# Altium schematic sheet 03_DRAM.SchDoc
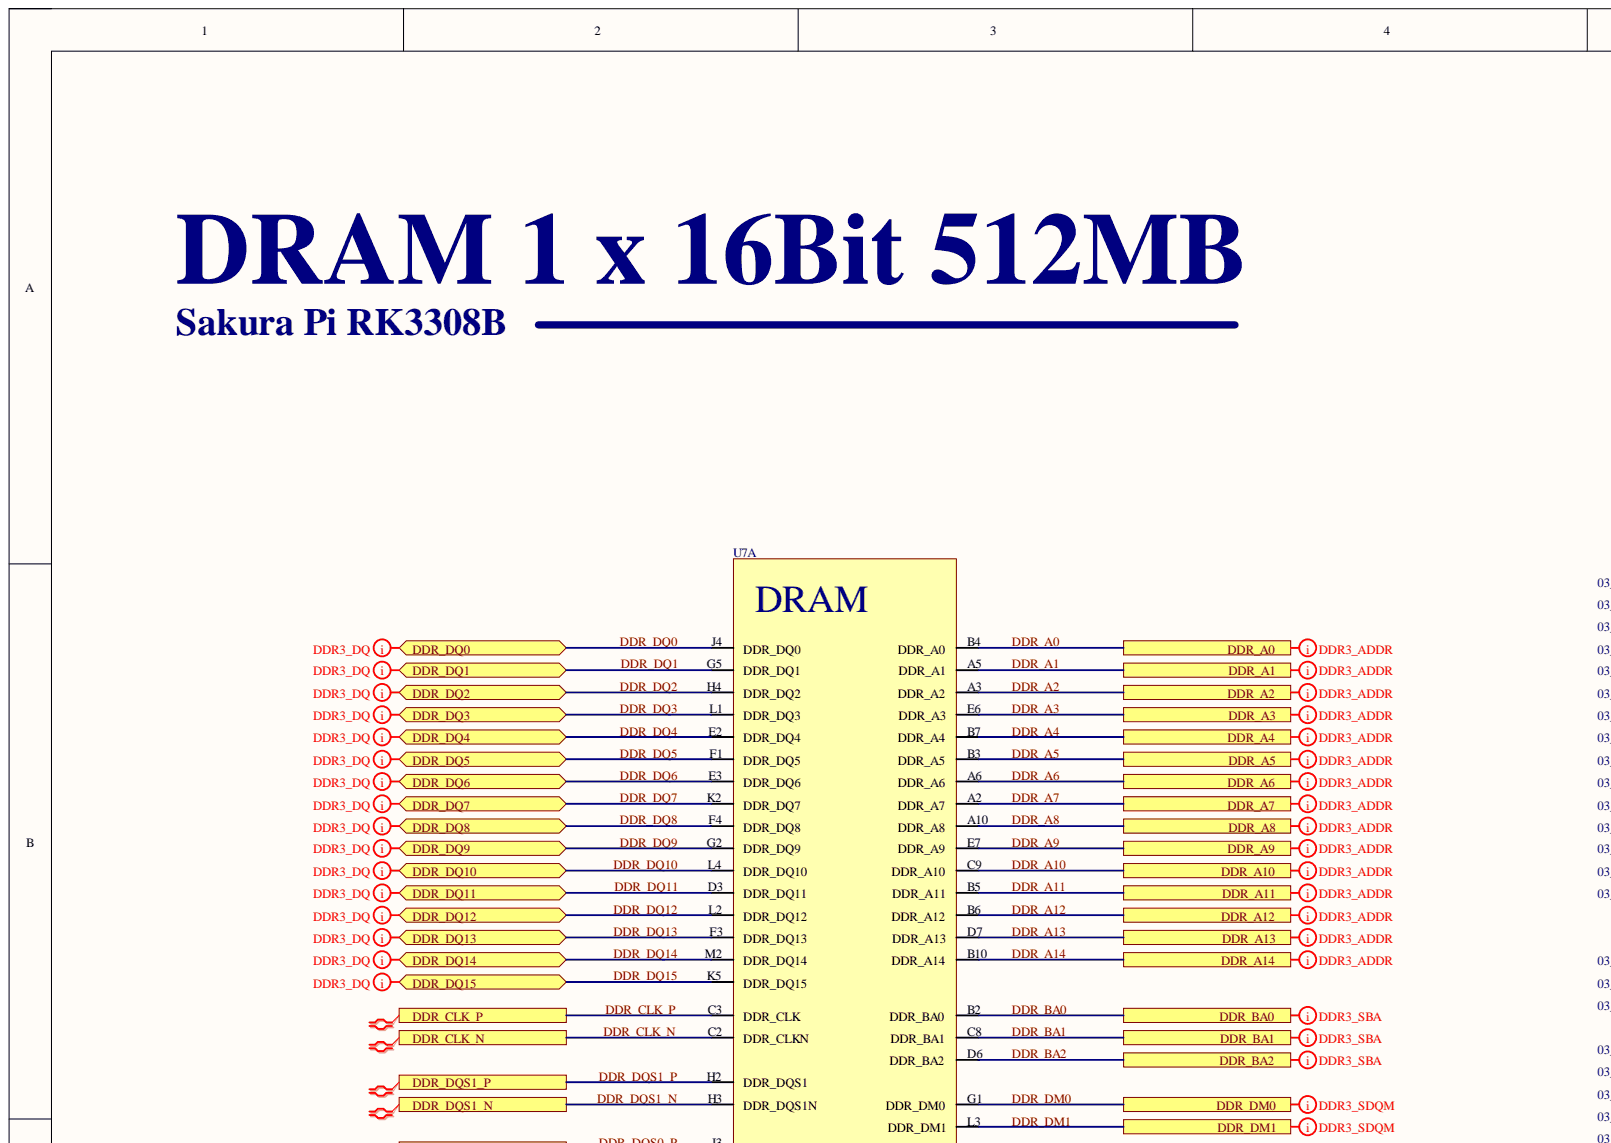
[diagram p0: 03_DRAM.SchDoc, top left]
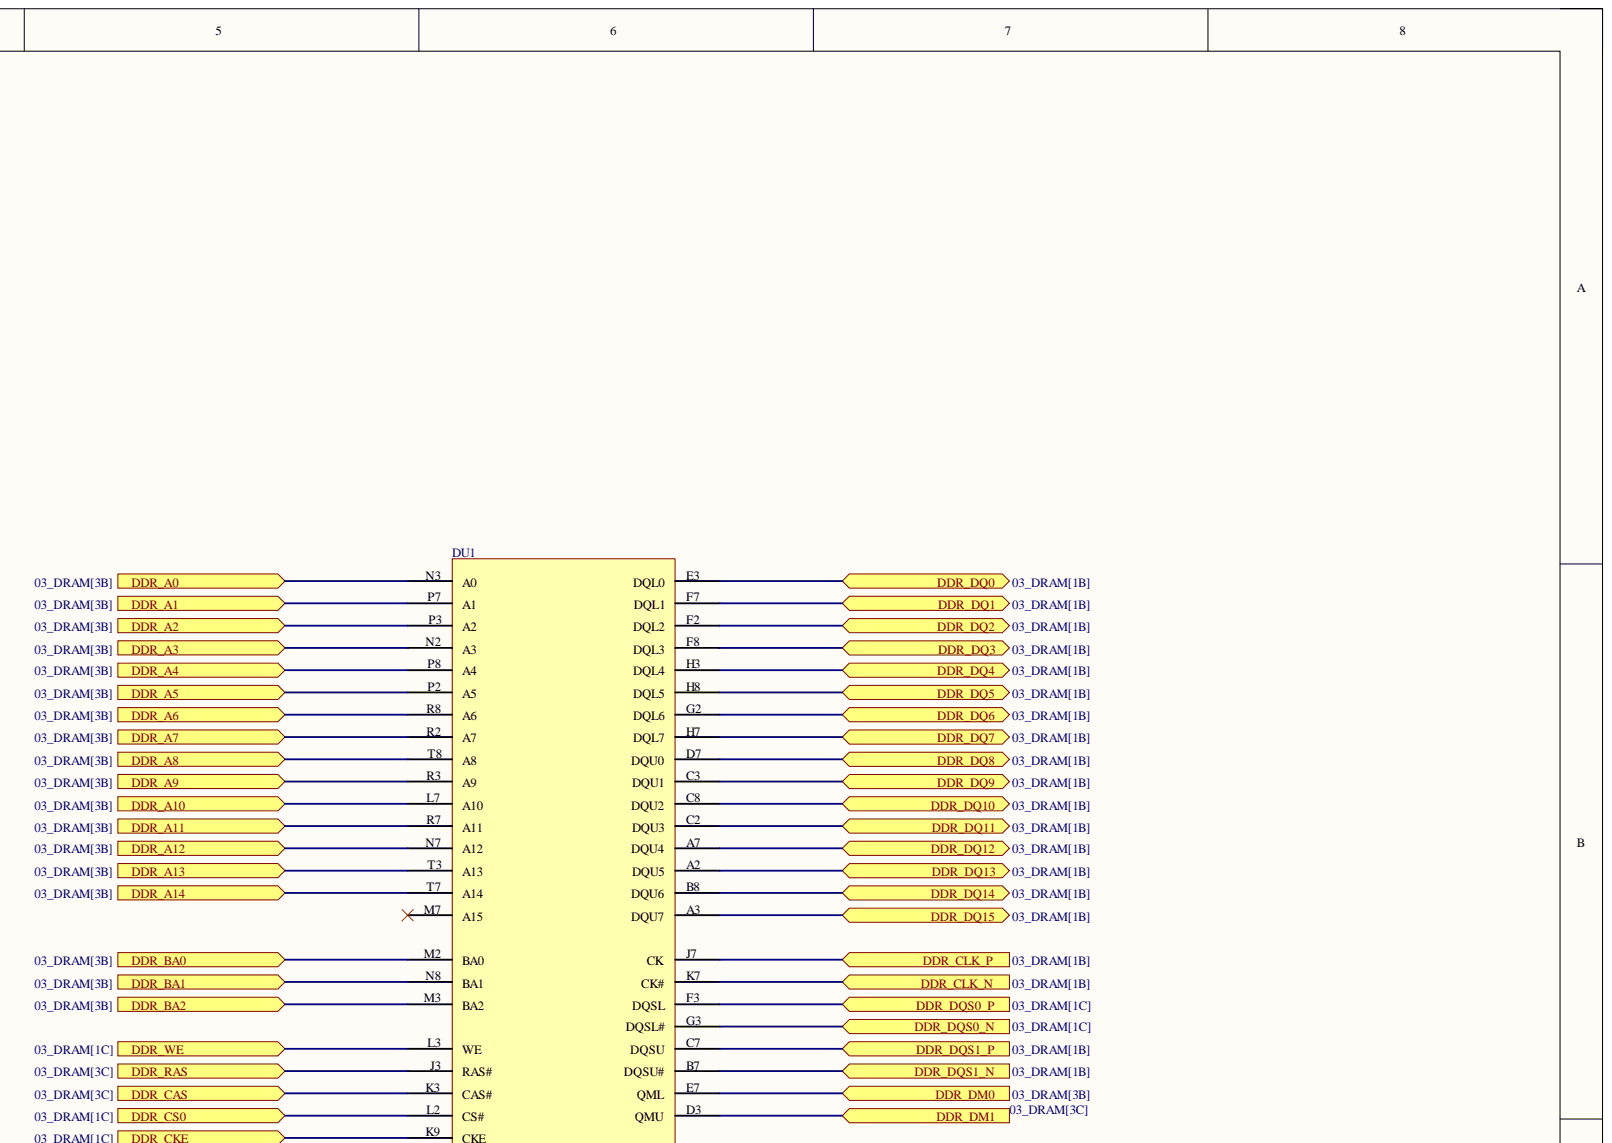
[diagram p1: 03_DRAM.SchDoc, top right]
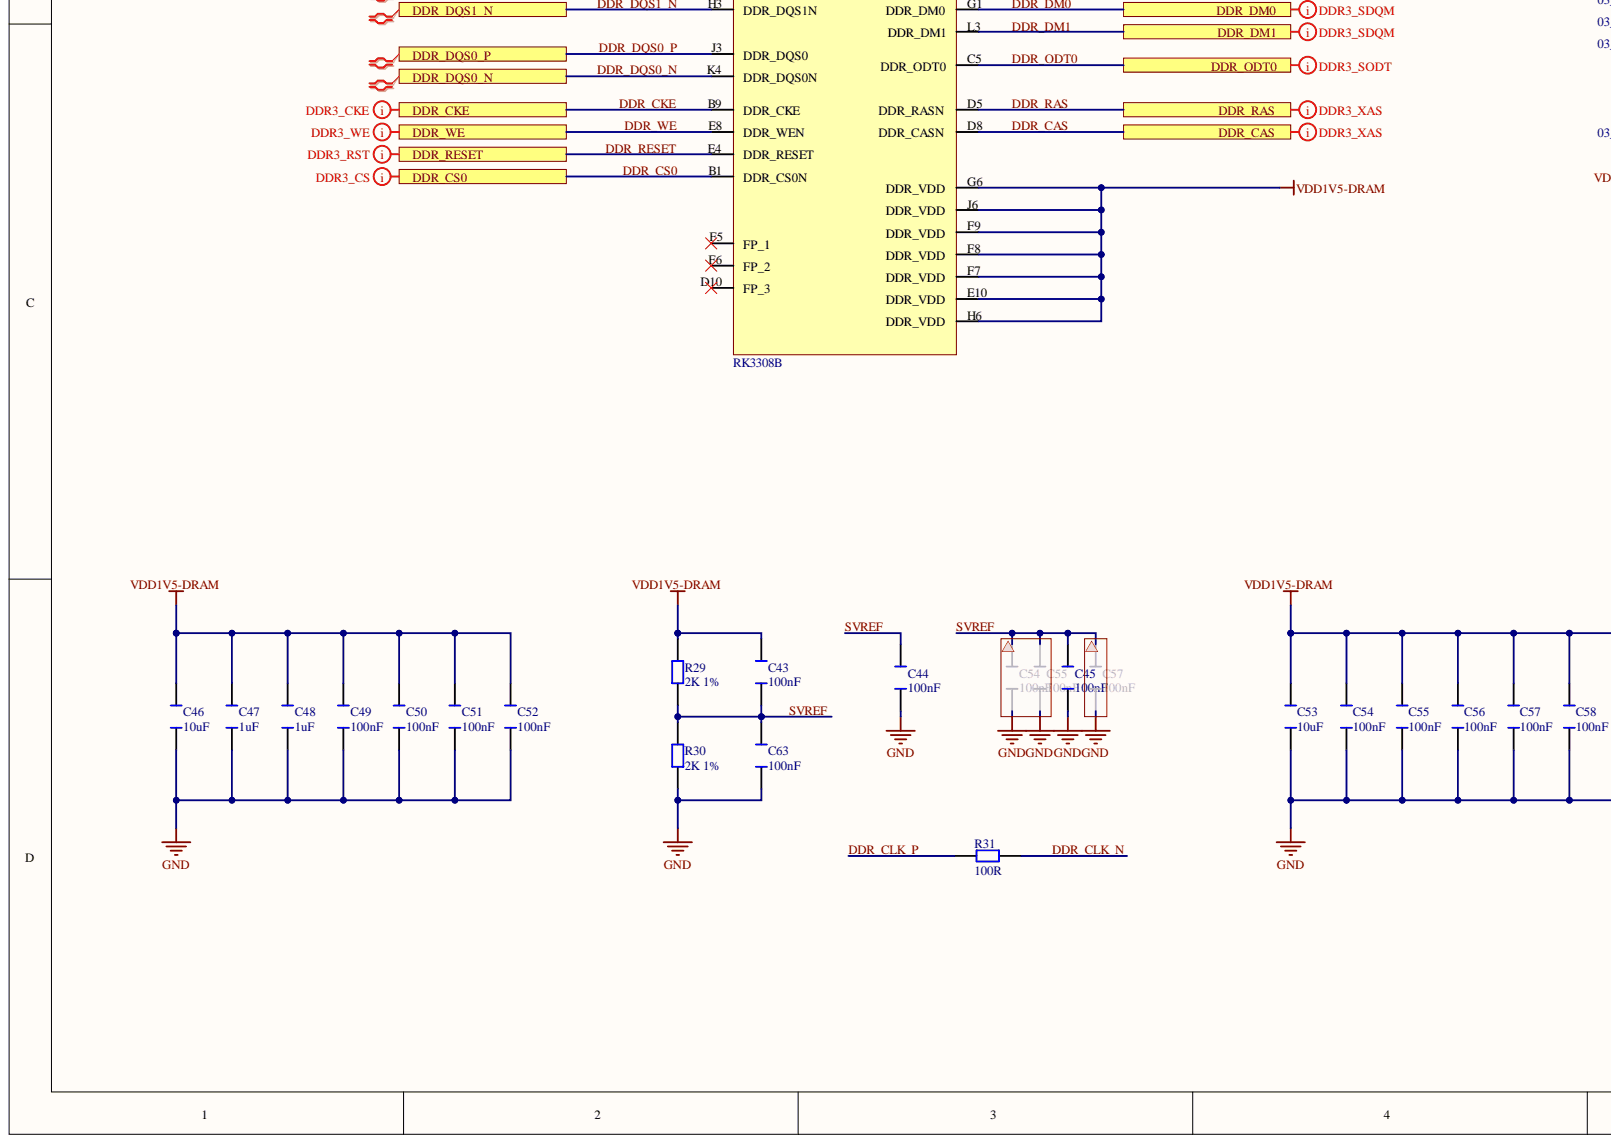
[diagram p2: 03_DRAM.SchDoc, bottom left]
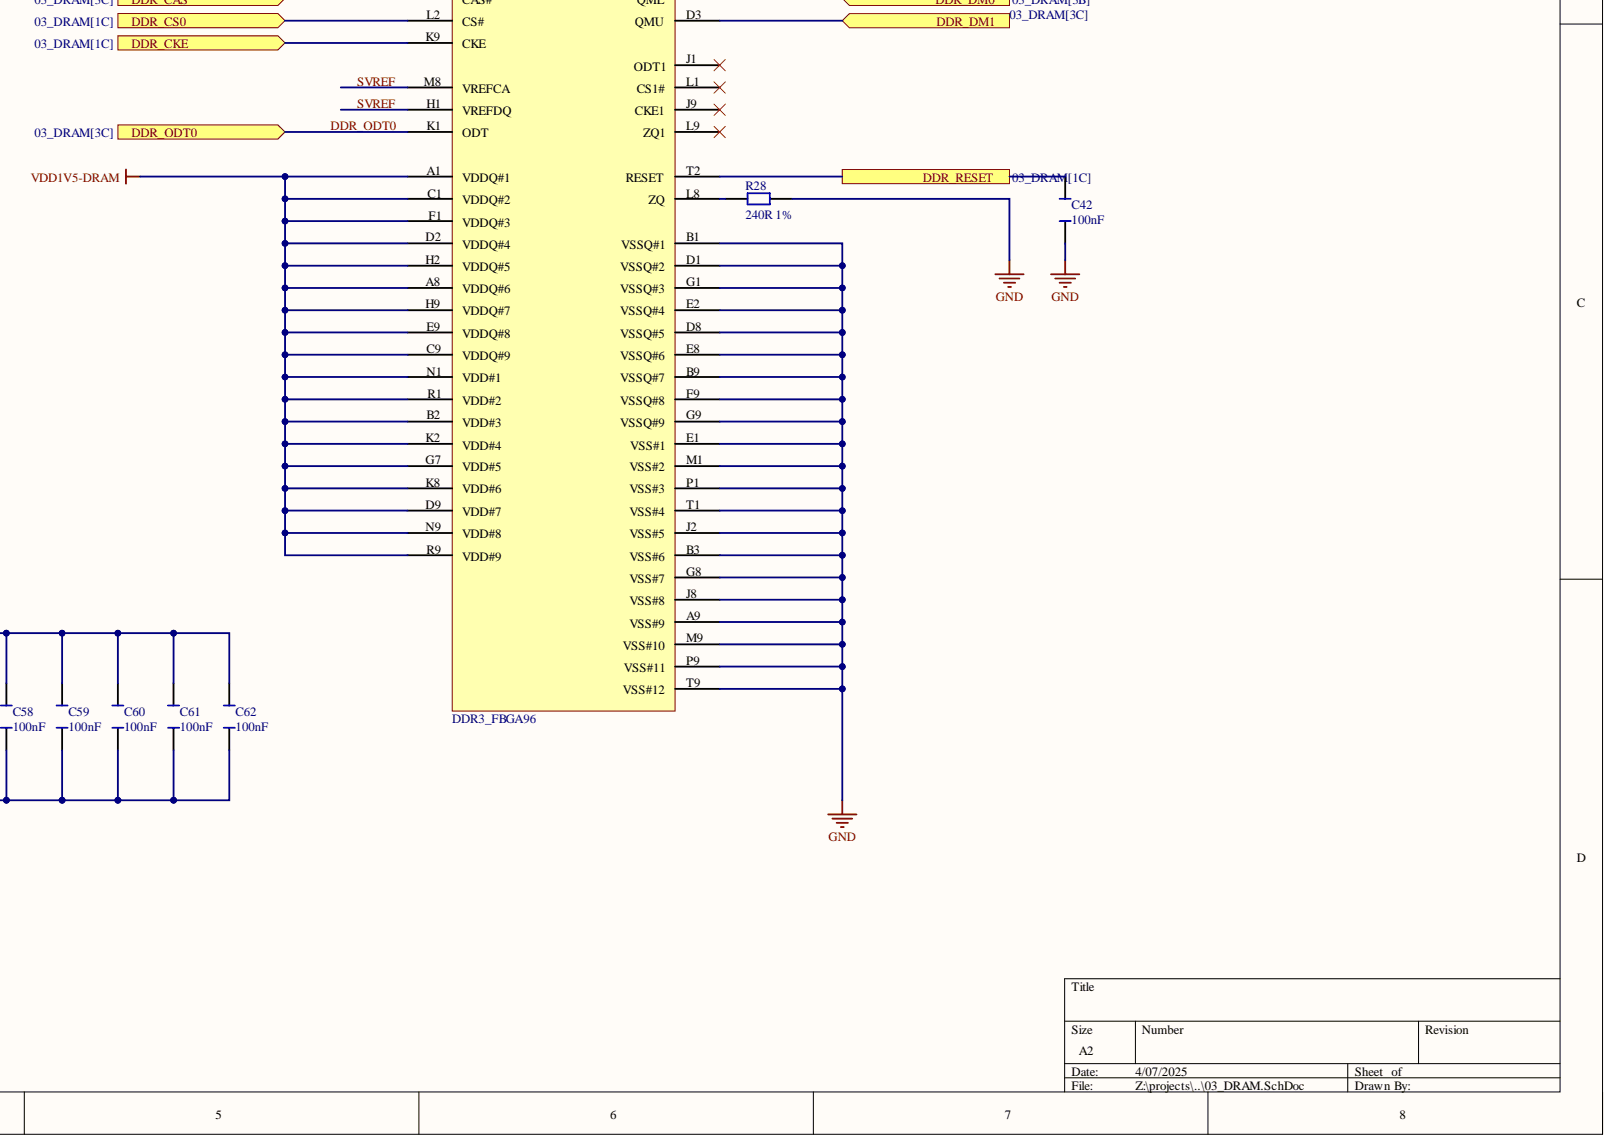
[diagram p3: 03_DRAM.SchDoc, bottom right]

## Parts
C42  100nF  [C0402]
C43  100nF  [C0402]
C44  100nF  [C0402]
C45  100nF  [C0402]
C46  10uF  [C0402]
C47  1uF  [C0402]
C48  1uF  [C0402]
C49  100nF  [C0402]
C50  100nF  [C0402]
C51  100nF  [C0402]
C52  100nF  [C0402]
C53  10uF  [C0402]
C54  100nF  [C0402]
C55  100nF  [C0402]
C56  100nF  [C0402]
C57  100nF  [C0402]
C58  100nF  [C0402]
C59  100nF  [C0402]
C60  100nF  [C0402]
C61  100nF  [C0402]
C62  100nF  [C0402]
C63  100nF  [C0402]
DU1  DDR3_FBGA96  [DDR3_FBGA96]
R28  240R 1%  [R0402]
R29  2K 1%  [R0402]
R30  2K 1%  [R0402]
R31  100R  [R0402]
U7  RK3308B  [RK3308B]  (part A)

## Ports
DDR_A0, DDR_A1, DDR_A2, DDR_A3, DDR_A4, DDR_A5, DDR_A6, DDR_A7, DDR_A8, DDR_A9, DDR_A10, DDR_A11, DDR_A12, DDR_A13, DDR_A14, DDR_BA0, DDR_BA1, DDR_BA2, DDR_CAS, DDR_CKE, DDR_CLK_N, DDR_CLK_P, DDR_CS0, DDR_DM0, DDR_DM1, DDR_DQ0, DDR_DQ1, DDR_DQ2, DDR_DQ3, DDR_DQ4, DDR_DQ5, DDR_DQ6, DDR_DQ7, DDR_DQ8, DDR_DQ9, DDR_DQ10, DDR_DQ11, DDR_DQ12, DDR_DQ13, DDR_DQ14, DDR_DQ15, DDR_DQS0_N, DDR_DQS0_P, DDR_DQS1_N, DDR_DQS1_P, DDR_ODT0, DDR_RAS, DDR_RESET, DDR_WE

## Nets
GND: C42.2 C44.1 C45.1 C46.1 C47.1 C48.2 C49.1 C50.1 C51.1 C52.1 C53.1 C54.1 C55.1 C56.1 C57.1 C58.1 C59.1 C60.1 C61.1 C62.1 C63.1 DU1.A9(VSS#9) DU1.B1(VSSQ#1) DU1.B3(VSS#6) DU1.B9(VSSQ#7) DU1.D1(VSSQ#2) DU1.D8(VSSQ#5) DU1.E1(VSS#1) DU1.E2(VSSQ#4) DU1.E8(VSSQ#6) DU1.F9(VSSQ#8) DU1.G1(VSSQ#3) DU1.G8(VSS#7) DU1.G9(VSSQ#9) DU1.J2(VSS#5) DU1.J8(VSS#8) DU1.M1(VSS#2) DU1.M9(VSS#10) DU1.P1(VSS#3) DU1.P9(VSS#11) DU1.T1(VSS#4) DU1.T9(VSS#12) R28.2 R30.1
VDD1V5-DRAM: C43.2 C46.2 C47.2 C48.1 C49.2 C50.2 C51.2 C52.2 C53.2 C54.2 C55.2 C56.2 C57.2 C58.2 C59.2 C60.2 C61.2 C62.2 DU1.A1(VDDQ#1) DU1.A8(VDDQ#6) DU1.B2(VDD#3) DU1.C1(VDDQ#2) DU1.C9(VDDQ#9) DU1.D2(VDDQ#4) DU1.D9(VDD#7) DU1.E9(VDDQ#8) DU1.F1(VDDQ#3) DU1.G7(VDD#5) DU1.H2(VDDQ#5) DU1.H9(VDDQ#7) DU1.K2(VDD#4) DU1.K8(VDD#6) DU1.N1(VDD#1) DU1.N9(VDD#8) DU1.R1(VDD#2) DU1.R9(VDD#9) R29.2 U7.E10(DDR_VDD) U7.F7(DDR_VDD) U7.F8(DDR_VDD) U7.F9(DDR_VDD) U7.G6(DDR_VDD) U7.H6(DDR_VDD) U7.J6(DDR_VDD)
DDR_A0: DU1.N3(A0) U7.B4(DDR_A0) ; port DDR_A0
DDR_A1: DU1.P7(A1) U7.A5(DDR_A1) ; port DDR_A1
DDR_A2: DU1.P3(A2) U7.A3(DDR_A2) ; port DDR_A2
DDR_A3: DU1.N2(A3) U7.E6(DDR_A3) ; port DDR_A3
DDR_A4: DU1.P8(A4) U7.B7(DDR_A4) ; port DDR_A4
DDR_A5: DU1.P2(A5) U7.B3(DDR_A5) ; port DDR_A5
DDR_A6: DU1.R8(A6) U7.A6(DDR_A6) ; port DDR_A6
DDR_A7: DU1.R2(A7) U7.A2(DDR_A7) ; port DDR_A7
DDR_A8: DU1.T8(A8) U7.A10(DDR_A8) ; port DDR_A8
DDR_A9: DU1.R3(A9) U7.E7(DDR_A9) ; port DDR_A9
DDR_A10: DU1.L7(A10) U7.C9(DDR_A10) ; port DDR_A10
DDR_A11: DU1.R7(A11) U7.B5(DDR_A11) ; port DDR_A11
DDR_A12: DU1.N7(A12) U7.B6(DDR_A12) ; port DDR_A12
DDR_A13: DU1.T3(A13) U7.D7(DDR_A13) ; port DDR_A13
DDR_A14: DU1.T7(A14) U7.B10(DDR_A14) ; port DDR_A14
DDR_BA0: DU1.M2(BA0) U7.B2(DDR_BA0) ; port DDR_BA0
DDR_BA1: DU1.N8(BA1) U7.C8(DDR_BA1) ; port DDR_BA1
DDR_BA2: DU1.M3(BA2) U7.D6(DDR_BA2) ; port DDR_BA2
DDR_CAS: DU1.K3(CAS#) U7.D8(DDR_CASN) ; port DDR_CAS
DDR_CKE: DU1.K9(CKE) U7.B9(DDR_CKE) ; port DDR_CKE
DDR_CLK_N: DU1.K7(CK#) R31.2 U7.C2(DDR_CLKN) ; port DDR_CLK_N
DDR_CLK_P: DU1.J7(CK) R31.1 U7.C3(DDR_CLK) ; port DDR_CLK_P
DDR_CS0: DU1.L2(CS#) U7.B1(DDR_CS0N) ; port DDR_CS0
DDR_DM0: DU1.E7(QML) U7.G1(DDR_DM0) ; port DDR_DM0
DDR_DM1: DU1.D3(QMU) U7.L3(DDR_DM1) ; port DDR_DM1
DDR_DQ0: DU1.E3(DQL0) U7.J4(DDR_DQ0) ; port DDR_DQ0
DDR_DQ1: DU1.F7(DQL1) U7.G5(DDR_DQ1) ; port DDR_DQ1
DDR_DQ2: DU1.F2(DQL2) U7.H4(DDR_DQ2) ; port DDR_DQ2
DDR_DQ3: DU1.F8(DQL3) U7.L1(DDR_DQ3) ; port DDR_DQ3
DDR_DQ4: DU1.H3(DQL4) U7.E2(DDR_DQ4) ; port DDR_DQ4
DDR_DQ5: DU1.H8(DQL5) U7.F1(DDR_DQ5) ; port DDR_DQ5
DDR_DQ6: DU1.G2(DQL6) U7.E3(DDR_DQ6) ; port DDR_DQ6
DDR_DQ7: DU1.H7(DQL7) U7.K2(DDR_DQ7) ; port DDR_DQ7
DDR_DQ8: DU1.D7(DQU0) U7.F4(DDR_DQ8) ; port DDR_DQ8
DDR_DQ9: DU1.C3(DQU1) U7.G2(DDR_DQ9) ; port DDR_DQ9
DDR_DQ10: DU1.C8(DQU2) U7.L4(DDR_DQ10) ; port DDR_DQ10
DDR_DQ11: DU1.C2(DQU3) U7.D3(DDR_DQ11) ; port DDR_DQ11
DDR_DQ12: DU1.A7(DQU4) U7.L2(DDR_DQ12) ; port DDR_DQ12
DDR_DQ13: DU1.A2(DQU5) U7.F3(DDR_DQ13) ; port DDR_DQ13
DDR_DQ14: DU1.B8(DQU6) U7.M2(DDR_DQ14) ; port DDR_DQ14
DDR_DQ15: DU1.A3(DQU7) U7.K5(DDR_DQ15) ; port DDR_DQ15
DDR_DQS0_N: DU1.G3(DQSL#) U7.K4(DDR_DQS0N) ; port DDR_DQS0_N
DDR_DQS0_P: DU1.F3(DQSL) U7.J3(DDR_DQS0) ; port DDR_DQS0_P
DDR_DQS1_N: DU1.B7(DQSU#) U7.H3(DDR_DQS1N) ; port DDR_DQS1_N
DDR_DQS1_P: DU1.C7(DQSU) U7.H2(DDR_DQS1) ; port DDR_DQS1_P
DDR_ODT0: DU1.K1(ODT) U7.C5(DDR_ODT0) ; port DDR_ODT0
DDR_RAS: DU1.J3(RAS#) U7.D5(DDR_RASN) ; port DDR_RAS
DDR_RESET: C42.1 DU1.T2(RESET) U7.E4(DDR_RESET) ; port DDR_RESET
DDR_WE: DU1.L3(WE) U7.E8(DDR_WEN) ; port DDR_WE
SVREF: C43.1 C44.2 C45.2 C63.2 DU1.H1(VREFDQ) DU1.M8(VREFCA) R29.1 R30.2
NetDU1_L8: DU1.L8(ZQ) R28.1

Not connected: DU1 J1(ODT1) J9(CKE1) L1(CS1#) L9(ZQ1) M7(A15); U7 D10(FP_3) F5(FP_1) F6(FP_2)

## Library data of the parts
C42-C45 C49-C52 C54-C63 = C_100NF: Component Kind: Standard; Library Name: rk3308b.SchLib
C46 C53 = C_10UF: Component Kind: Standard; Library Name: Board.SchLib
C47 C48 = C_1UF: Component Kind: Standard; Library Name: Board.SchLib
R28 = R_240R_P1: Component Kind: Standard; Library Name: rk3308b.SchLib
R29 R30 = R_2K_P1: Component Kind: Standard; Library Name: Board.SchLib
R31 = R_100R: Component Kind: Standard; Library Name: rk3308b.SchLib
DU1 = DDR3_FBGA96: Component Kind: Standard; Library Name: rk3308b.SchLib
U7 = RK3308B: Component Kind: Standard; Library Name: rk3308b.SchLib
